# Altium schematic sheet 01_Power_LDO.SchDoc(01_Power_LDO)
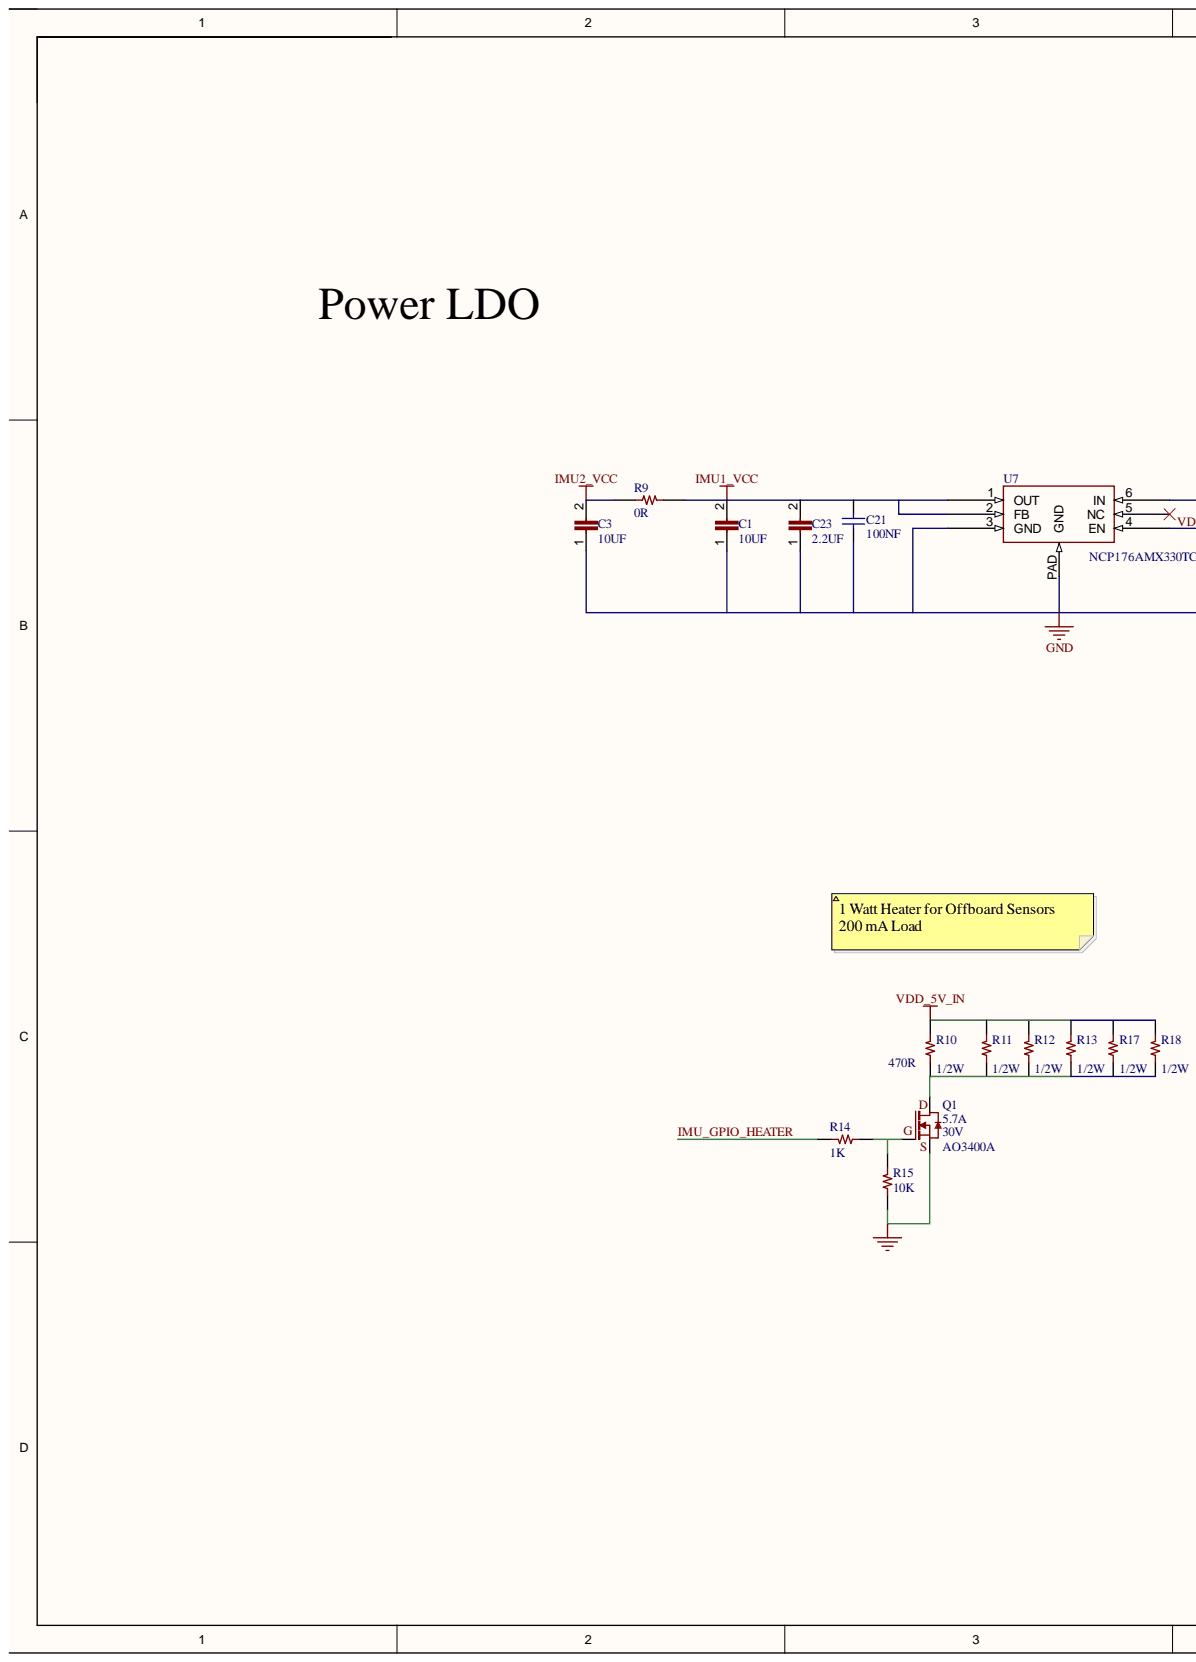
[diagram p0: 01_Power_LDO.SchDoc, left]
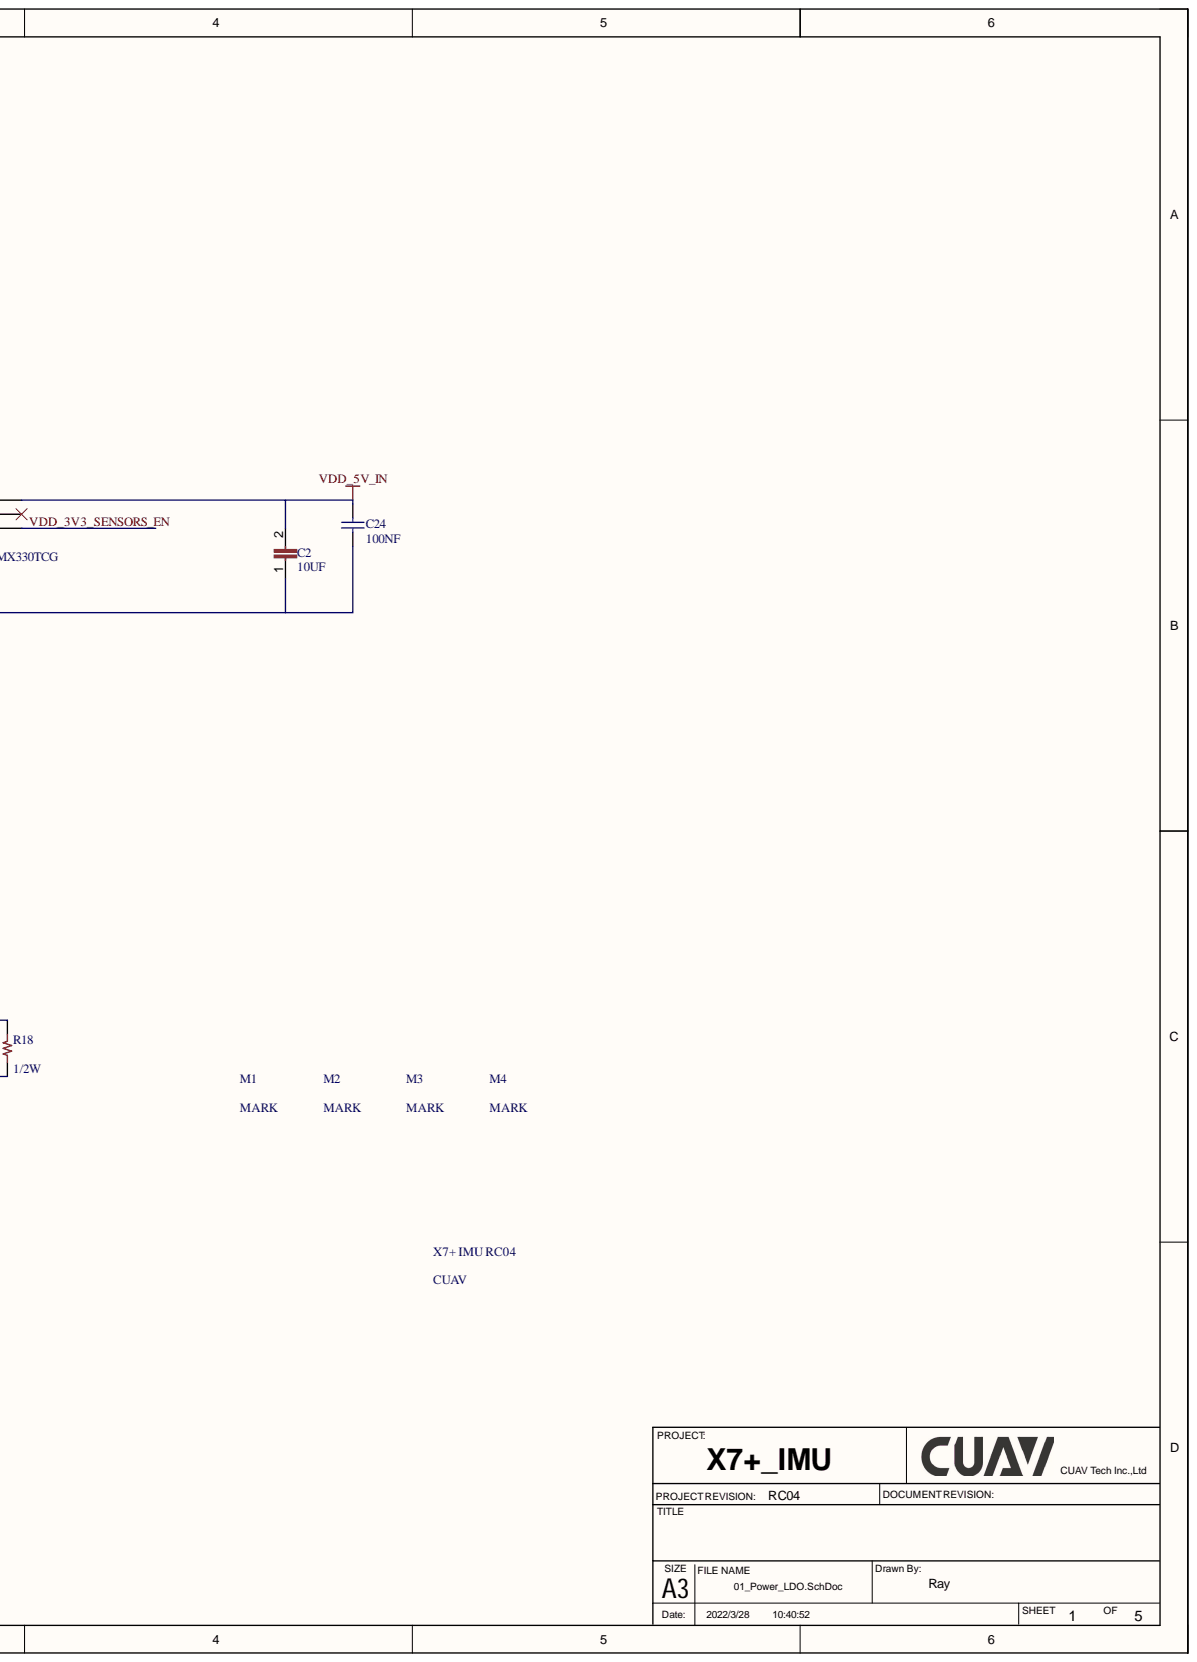
[diagram p1: 01_Power_LDO.SchDoc, right]

## Parts
C1  10UF  [0603C]
C2  10UF  [0603C]
C3  10UF  [0603C]
C21  100NF  [0402CAP]
C23  2.2UF  [0402C]
C24  100NF  [0402CAP]
M1  MARK  [MARK]
M2  MARK  [MARK]
M3  MARK  [MARK]
M4  MARK  [MARK]
Q1  AO3400A  Current: 5.7A  Voltage: 30V  [SOT23]
R9  0R  [0402R]
R10  470R  Power: 1/2W  [R0805]
R11  Power: 1/2W  [R0805]  (hidden comment: 470R)
R12  Power: 1/2W  [R0805]  (hidden comment: 470R)
R13  Power: 1/2W  [R0805]  (hidden comment: 470R)
R14  1K  [0402r]
R15  10K  [0402r]
R17  Power: 1/2W  [R0805]  (hidden comment: 470R)
R18  Power: 1/2W  [R0805]  (hidden comment: 470R)
U7  NCP176AMX330TCG  [XDFN6_0.4]
X7+_IMU_RC04  CUAV  [CUAV]

## Nets
GND: C1.1 C2.1 C3.1 C21.2 C23.1 C24.2 Q1.2 R15.2 U7.3(GND) U7.PAD(GND)
IMU1_VCC: C1.2 C21.1 C23.2 R9.1 U7.1(OUT) U7.2(FB)
IMU2_VCC: C3.2 R9.2
VDD_5V_IN: C2.2 C24.1 R10.1 R11.1 R12.1 R13.1 R17.1 R18.1 U7.6(IN)
IMU_GPIO_HEATER: R14.1
VDD_3V3_SENSORS_EN: U7.4(EN)
NetQ1_1: Q1.1 R14.2 R15.1
NetQ1_3: Q1.3 R10.2 R11.2 R12.2 R13.2 R17.2 R18.2

Not connected: U7 5(NC)

## Library data of the parts
C1-C3 = 0603C10UF: 0603 CAP 10UF 16V 10%; Component Kind: Standard; Library Name: CUAV.SchLib
C21 C24 = Cap: 0402 100NF 50V 10%; Value: 100pF; Component Kind: Standard; LatestRevisionDate: 17-Jul-2002; LatestRevisionNote: Re-released for DXP Platform.; Library Name: Miscellaneous Devices.IntLib; PackageDescription: Radial Cap, Thru-Hole; 2 Leads; 0.3 in Pin Spacing; PackageReference: RAD-0.3; Published: 8-Jun-2000; Signal Integrity: Cap; Simulation: CAP; Publisher: Altium Limited
C23 = 0402C2.2UF: 0402 CAP 2.2UF 16V 5%; Component Kind: Standard; Library Name: CUAV.SchLib
Q1 = TRAN_MOSFET_N-CH_AO3400A_SMT: TRANSISTOR MOSFET N-CH 30V 5.7A SOT23; Manufacturer: Alpha & Omega Semiconductor Inc.; Category: Discrete Semiconductor Products; Component Kind: Standard; ComponentLink1Description: https://www.digikey.com/products/en/discrete-semiconductor-products/transistors-fets-mosfets-single/278?k=&pkeyword=&pv2068=u30V&pv608=2552&sf=0&FV=ffe00116%2Cfffc0311%2C1c0002&quantity=&ColumnSort=0&page=1&pageSize=25; ComponentLink1URL: https://www.digikey.com/products/en/discrete-semiconductor-products/transistors-fets-mosfets-single/278?k=&pkeyword=&pv2068=u30V&pv608=2552&sf=0&FV=ffe00116%2Cfffc0311%2C1c0002&quantity=&ColumnSort=0&page=1&pageSize=25; Library Name: My_Integrated_Library.SchLib; Manufacturer Part Number: AO3400A; Packaging: Cut Tape (CT); Part Status: Active; Pricing 1: 1=0.49, 10=0.354, 100=0.2359, 500=0.14414, 1000=0.11356 (USD); Stock 1: 545345; Supplier 1: Digi-Key; Supplier Part Number 1: 785-1000-1-ND
R9 = 0402R0R: R0402 0R 1%; Component Kind: Standard; Library Name: CUAV.SchLib
R10-R13 R17 R18 = RES_100_1%_1/2W_0805: Manufacturer: Yageo; Manufacturer Part Number: SR0805FR-47100RL; Tolerance: ±1%; Category: Resistors; Component Kind: Standard; ComponentLink1Description: https://www.digikey.com/product-detail/en/yageo/SR0805FR-47100RL/YAG5845CT-ND/9373230; ComponentLink1URL: https://www.digikey.com/product-detail/en/yageo/SR0805FR-47100RL/YAG5845CT-ND/9373230; Library Name: My_Integrated_Library.IntLib; Operating Temperature: -55°C ~ 155°C; Packaging: Cut Tape (CT); Part Status: Active; Pricing 1: 1=0.1, 10=0.022, 100=0.0088, 1000=0.00396, 2500=0.00344 (USD); Stock 1: 9649084; Supplier 1: Digi-Key; Supplier Part Number 1: YAG5845CT-ND
R14 = RES_1K_1%_1/16W_0402: RES SMD 1K OHM 1% 1/16W 0402; Manufacturer: Yageo; Manufacturer Part Number: RC0402FR-071KL; Category: Resistors; Component Kind: Standard; ComponentLink1Description: https://www.digikey.com/product-detail/en/yageo/RC0402FR-071KL/311-1.00KLRCT-ND/729460; ComponentLink1URL: https://www.digikey.com/product-detail/en/yageo/RC0402FR-071KL/311-1.00KLRCT-ND/729460; Library Name: My_Integrated_Library.IntLib; Operating Temperature: -55°C ~ 155°C; Packaging: Cut Tape (CT); Part Status: Active; Power: 1/16W; Pricing 1: 1=0.1, 10=0.014, 100=0.0056, 1000=0.00253, 2500=0.0022, 5000=0.00181 (USD); Stock 1: 18685853; Supplier 1: Digi-Key; Supplier Part Number 1: 311-1.00KLRCT-ND; Tolerance: ±1%; Value: 1K
R15 = RES_10K_1%_1/16W_0402: RES SMD 10K OHM 1% 1/16W 0402; Manufacturer: Yageo; Manufacturer Part Number: RC0402FR-0710KL; Category: Resistors; Component Kind: Standard; ComponentLink1Description: https://www.digikey.com/products/en?keywords=311-10.0KLRCT-ND; ComponentLink1URL: https://www.digikey.com/products/en?keywords=311-10.0KLRCT-ND; Library Name: My_Integrated_Library.SchLib; Operating Temperature: -55°C ~ 155°C; Packaging: Cut Tape (CT); Part Status: Active; Power: 1/16W; Pricing 1: 1=0.1, 10=0.014, 100=0.0056, 1000=0.00253, 2500=0.0022, 5000=0.00181 (USD); Stock 1: 37996194; Supplier 1: Digi-Key; Supplier Part Number 1: 311-10.0KLRCT-ND; Tolerance: ±1%; Value: 10K
M1-M4 = MARK: Component Kind: Standard; Library Name: CUAV.SchLib
U7 = NCP176AMX330TCG: Component Kind: Standard; Library Name: CUAV.SchLib
X7+_IMU_RC04 = CUAV: Component Kind: Standard; Library Name: CUAV.SchLib
